# Altium schematic sheet pca10036_sheet5_power_supply.SchDoc
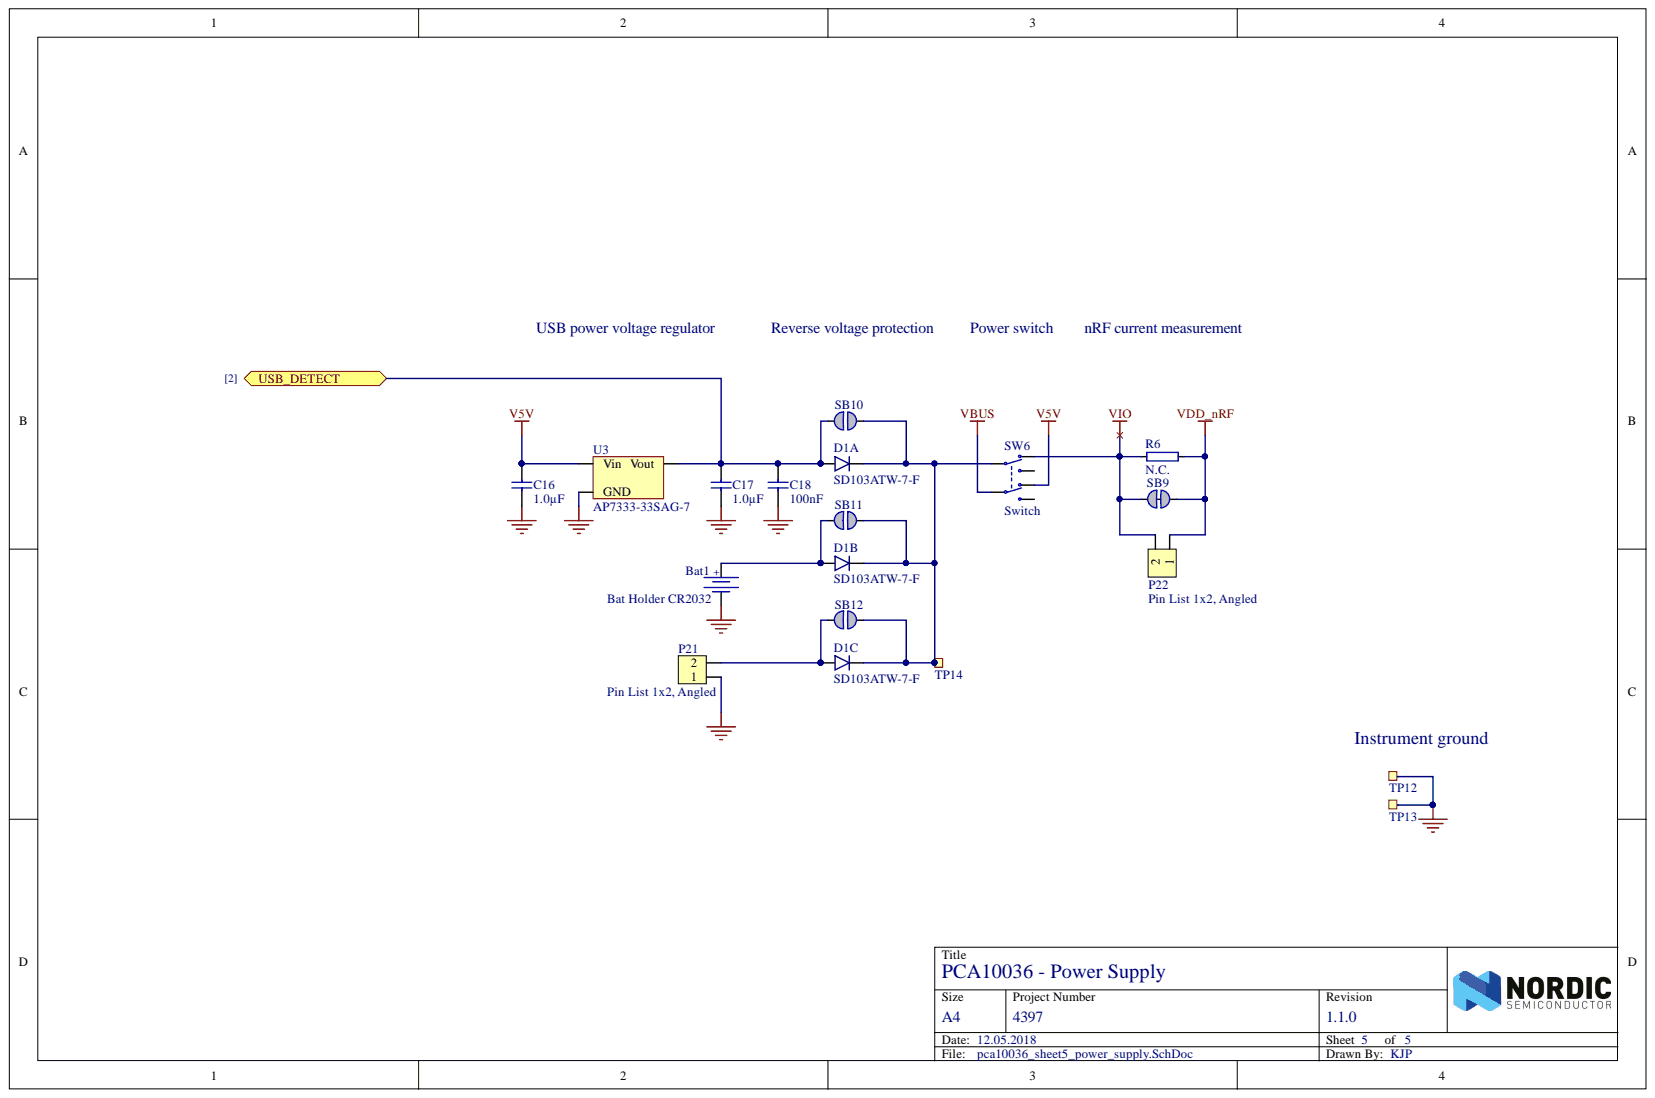
[diagram p0: pca10036_sheet5_power_supply.SchDoc]

## Parts
Bat1  Bat Holder CR2032  [CR2032_HOLDER_SMD]
C16  1.0µF  [CAPC1608X06L]
C17  1.0µF  [CAPC1608X06L]
C18  100nF  [CAPC1005X04L]
D1  SD103ATW-7-F  [SOT-363]  (parts A,B,C)
P21  Pin List 1x2, Angled  [LIST_ANG_1x2-SMD-2.54MM]
P22  Pin List 1x2, Angled  [LIST_ANG_1x2-SMD-2.54MM]
R6  N.C.  [RESC1005X04L]
SB9  Solderbridge  [Solderbridge_Shorted]  (value not drawn)
SB10  Solderbridge  [Solderbridge]  (value not drawn)  (no BOM)
SB11  Solderbridge  [Solderbridge]  (value not drawn)  (no BOM)
SB12  Solderbridge  [Solderbridge]  (value not drawn)  (no BOM)
SW6  Switch  [JS202011SCQN]
TP12  TP  [TESTPAD-TH]  (no BOM)
TP13  TP  [TESTPAD-TH]  (no BOM)
TP14  Ø1.0mm,SMD  [TP_SMD_Ø1.0mm]  (value not drawn)  (no BOM)
U3  AP7333-33SAG-7  [SOT-23A]

## Ports
USB_DETECT

## Nets
GND: Bat1.2 C16.1 C17.1 C18.1 P21.1 TP12.1 TP13.1 U3.3
V5V: C16.2 SW6.6 U3.1
VBUS: SW6.5
VDD_nRF: P22.1 R6.2 SB9.1
VIO: P22.2 R6.1 SB9.2 SW6.3
USB_DETECT: C17.2 C18.2 D1.6 SB10.2 U3.2 ; port USB_DETECT
NetBat1_1: Bat1.1 D1.5 SB11.2
NetD1_1: D1.1 D1.2 D1.3 SB10.1 SB11.1 SB12.1 SW6.2 TP14.1
NetD1_4: D1.4 P21.2 SB12.2

Not connected: SW6 1 4

## Library data of the parts
Bat1 = Bat Holder CR2032 SMD: 2nd source part number: 69-525-27; Manufacturer: Keystone; Manufacturer Part Number: 3002; Artikkelnr Noca: 34019038; Artikkelnr Simpro: N.A.; Database Table Name: Connectors$; 2nd source Supplier: Elfa; Component Kind: Standard; Datasheet: http://www.farnell.com/datasheets/45480.pdf; Description: Bat Holder CR2032 SMD; Footprint Doc: CR2032_HOLDER_SMD; LatestRevisionDate: 2011-05-11; Library Name: Nordic Passive DB.DbLib; Note: Bat Holder CR2032; Part Number: Bat Holder CR2032 SMD; Pin Count: 3; Published: 2009-12-12; Supplier: Farnell; Supplier part number: 165-0693; Value: Bat Holder CR2032; Publisher: Nordic Semiconductor
C16 C17 = C0603-1µ0: Capacitor, X7R, ±10%; Artikkelnr Noca: 20039335; Artikkelnr Simpro: N.A.; Database Table Name: Capacitors$; Note: 16V; Component Kind: Standard; Footprint Doc: 0603; LatestRevisionDate: 2013-06-05; Library Name: Nordic Passive DB.DbLib; Part Number: C0603-1µ0; Pin Count: 2; Published: 2009-05-08; Value: 1.0µF; Publisher: Nordic Semiconductor
C18 = C0402-100n: Capacitor, X7R, ±10%; Artikkelnr Noca: 20039200; Artikkelnr Simpro: N.A.; Database Table Name: Capacitors$; Note: 16V; Component Kind: Standard; Footprint Doc: 0402; LatestRevisionDate: 2009-11-23; Library Name: Nordic Passive DB.DbLib; Part Number: C0402-100n; Pin Count: 2; Published: 2009-05-08; Value: 100nF; Publisher: Nordic Semiconductor
D1 = SD103ATW-7-F: Surface Mount Schottky Barrier Diode Array; 2nd source part number: 177-3507; Manufacturer: Diodes Incorporated; Artikkelnr Noca: 10029109; Artikkelnr Simpro: N.A.; Database Table Name: Semiconductors$; 2nd source Supplier: Farnell; Component Kind: Standard; Datasheet: http://www.diodes.com/datasheets/ds30374.pdf; Footprint Doc: SOT-363; LatestRevisionDate: 2012-06-15; Library Name: Nordic Discrete DB.DbLib; Manufacturer Part Number: SD103ATW-7-F; Part Number: SD103ATW-7-F; Published: 2012-06-15; Supplier: Digi-Key; Supplier part number: SD103ATW-FDITR-ND; Value: SD103ATW-7-F; Publisher: Nordic Semiconductor
P21 P22 = Pin List Ang 1x2 SMD, 2.54mm: Pin List 1x2, 2.54mm (100mil), Right Angled, SMD; 2nd source part number: S1113E-02-ND; Artikkelnr Noca: N.A.; Database Table Name: Connectors$; 2nd source Supplier: Digi-Key; Artikkelnr Simpro: N.A.; Component Kind: Standard; Footprint Doc: LIST_ANG_1x2-SMD-2.54MM; LatestRevisionDate: 2014-04-30; Library Name: Nordic Passive DB.DbLib; Part Number: Pin List Ang 1x2 SMD, 2.54mm; Pin Count: 2; Published: 2014-04-30; Supplier: Samtec; Supplier part number: TSM-102-01-L-SH-A; Value: Pin List 1x2, Angled; Publisher: Nordic Semiconductor
R6 = R0402-N.C.: Not mounted; Artikkelnr Noca: N.A.; Database Table Name: Resistors$; Note: Chip Res; Artikkelnr Simpro: N.A.; Component Kind: Standard; Footprint Doc: 0402; LatestRevisionDate: 2009-05-08; Library Name: Nordic Passive DB.DbLib; Part Number: R0402-N.C.; Pin Count: 2; Published: 2009-05-08; Value: N.C.; Publisher: Nordic Semiconductor
SB9 = Solderbridge Shorted: Shorted Solderbridge; Artikkelnr Noca: N.A.; Database Table Name: Connectors$; Artikkelnr Simpro: N.A.; Component Kind: Net Tie; Footprint Doc: Solderbridge_Shorted; LatestRevisionDate: 2012-08-07; Library Name: Nordic Passive DB.DbLib; Part Number: Solderbridge Shorted; Pin Count: 2; Published: 2012-08-07; Value: Solderbridge; Publisher: Nordic Semiconductor
SB10-SB12 = Solderbridge: Artikkelnr Noca: N.A.; Database Table Name: Connectors$; Artikkelnr Simpro: N.A.; Description: Solderbridge; Footprint Doc: Solderbridge; LatestRevisionDate: 2009-12-14; Library Name: Nordic Passive DB.DbLib; Part Number: Solderbridge; Pin Count: 2; Published: 2009-12-14; Value: Solderbridge; Publisher: Nordic Semiconductor
SW6 = JS202011SCQN: SMD slide Switch (ON-ON); 2nd source part number: 232-0019; Manufacturer: C&K Components; Artikkelnr Noca: N.A.; Database Table Name: Rele_Switch$; 2nd source Supplier: Farnell; Artikkelnr Simpro: N.A.; Component Kind: Standard; Datasheet: http://www.ck-components.com/14398/js_14july11.pdf/; Footprint Doc: JS202011SCQN; LatestRevisionDate: 2014-06-24; Library Name: Nordic Discrete DB.DbLib; Manufacturer Part Number: JS202011SCQN; Part Number: JS202011SCQN; Pin Count: 6; Published: 2014-06-24; Supplier: Digi-Key; Supplier part number: 401-2002-1-ND; Value: Switch; Publisher: Nordic Semiconductor
TP12 TP13 = Testpoint TH: Testpoint, through hole; Artikkelnr Noca: N.A.; Database Table Name: Connectors$; Artikkelnr Simpro: N.A.; Footprint Doc: TESTPAD-TH; LatestRevisionDate: 2009-12-12; Library Name: Nordic Passive DB.DbLib; Part Number: Testpoint TH; Pin Count: 1; Published: 2009-12-12; Value: TP; Publisher: Nordic Semiconductor
TP14 = TP_SMD_Ø1.0MM: 1.0mm circular SMD testpad; Manufacturer: Nordic Semiconductor; Artikkelnr Noca: N.A.; Database Table Name: Connectors$; Artikkelnr Simpro: N.A.; Footprint Doc: TP_SMD_Ø1.0mm; LatestRevisionDate: 2013-06-11; Library Name: Nordic Passive DB.DbLib; Part Number: TP_SMD_Ø1.0MM; Pin Count: 1; Published: 2013-06-11; Value: Ø1.0mm,SMD; Publisher: Nordic Semiconductor
U3 = AP7333-33SAG-7: 300mA, Low Quiescent Current, Fast Transient Low Dropout Linear Regulator; Manufacturer: Diodes Incorporated; Artikkelnr Noca: 17039216; Artikkelnr Simpro: N.A.; Database Table Name: Misc IC$; Component Kind: Standard; Datasheet: http://www.diodes.com/datasheets/AP7333.pdf; Footprint Doc: SOT-23-3; LatestRevisionDate: 2012-06-15; Library Name: Nordic Active DB.DbLib; Manufacturer Part Number: AP7333-33SAG-7; Part Number: AP7333-33SAG-7; Pin Count: 3; Published: 2012-06-15; Supplier: Digi-Key; Supplier part number: AP7333-33SAG-7DICT-ND; Value: AP7333-33SAG-7; Publisher: Nordic Semiconductor
